# Altium schematic sheet 1. Power.SchDoc("1. Power")
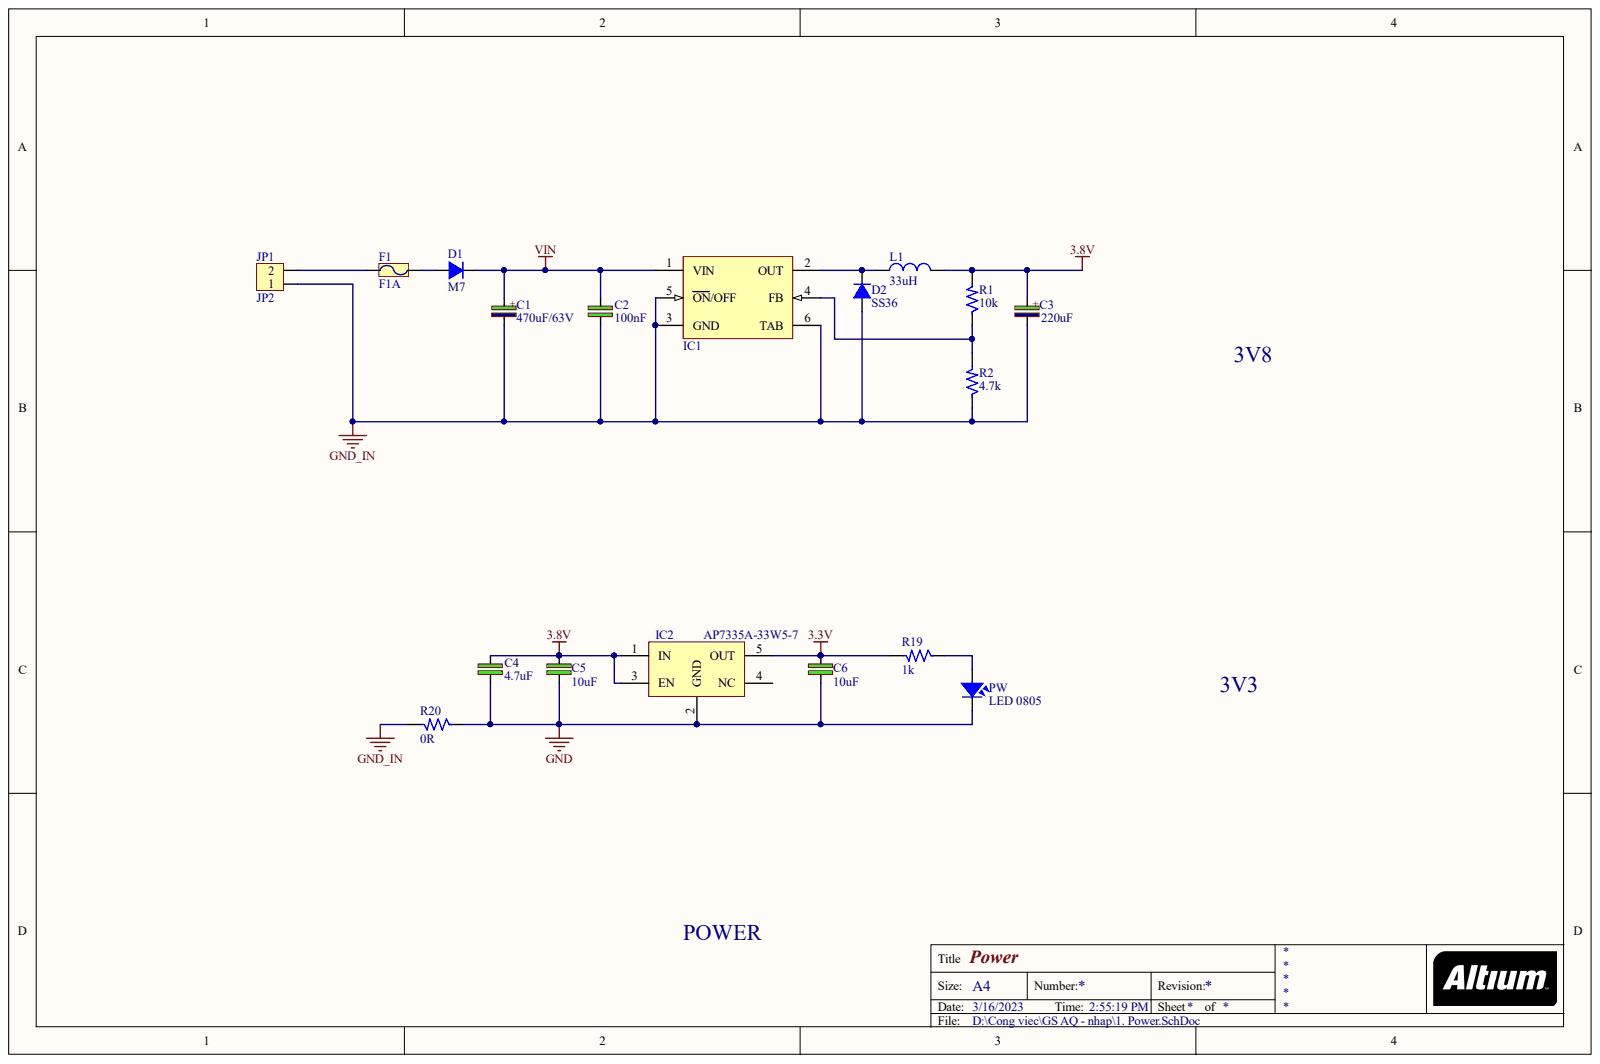
[diagram p0: 1. Power.SchDoc]

## Parts
C1  470uF/63V  [CP_1000uF_25V]
C2  value 100nF  [C-0805]  (hidden comment: 4.7uF)
C3  220uF  [EEFSDE]
C4  4.7uF  [C-0805]
C5  10uF  [C-0805]
C6  10uF  [C-0805]
D1  M7  [SMA11]
D2  SS36  [SMA11]
F1  F1A  [Fuse3]
IC1  LM2576  [TO-263]  (value not drawn)
IC2  AP7335A-33W5-7  [SOT23-5]
JP1  JP2  [KF2-5.08]
L1  33uH  [XL-cd74]
PW  LED 0805  [LED-0805]
R1  10k  [R-0805]
R2  4.7k  [R-0805]
R19  1k  [R-0805]
R20  0R  [R-0805]

## Nets
3.3V: C6.1 IC2.5(OUT) R19.2
3.8V: C3.1 C4.2 C5.1 IC2.1(IN) IC2.3(EN) L1.2 R1.2
GND: C4.1 C5.2 C6.2 IC2.2(GND) PW.2 R20.1
GND_IN: C1.2 C2.1 C3.2 D2.1 IC1.3(GND) IC1.5(ON/OFF) IC1.6(TAB) JP1.1 R2.1 R20.2
VIN: C1.1 C2.2 D1.2 IC1.1(VIN)
NetD1_1: D1.1 F1.2
NetD2_2: D2.2 IC1.2(OUT) L1.1
NetF1_1: F1.1 JP1.2
NetIC1_4: IC1.4(FB) R1.1 R2.2
NetPW_1: PW.1 R19.1

Not connected: IC2 4(NC)

## Library data of the parts
C1 = CP3: Cap 470uF/63V; Component Kind: Standard; Value: Cap 470uF/63V; Library Name: Anh_Trung.SchLib
C2 C4 = C: 4.7µF ±10% 35V Ceramic Capacitor X5R 0805 (2012 Metric); Manufacturer: Samsung Electro-Mechanics; Part number: CL21A475KLCLQNC; User_Description: CAP CER 4.7UF 35V X5R 0805; Category: CAPACITOR; Component Kind: Standard; Package: 0805; Library Name: Anh_Trung.SchLib
  C4: Value: 4.7uF
C3 = CP5: 220µF Molded Tantalum Polymer Capacitor 16V 2917 (7343 Metric) 40mOhm @ 100kHz; Manufacturer: AVX Corporation; Part number: TCME227M016R0040E; User_Description: CAP TANT POLY 220UF 16V 2917; Category: CAPACITOR; Component Kind: Standard; Package: 2917; Value: 220uF; Library Name: Anh_Trung.SchLib
C5 C6 = C: Cap SMD; Manufacturer: Samsung Electro-Mechanics; Part number: CL21A106KPFNNNG; User_Description: CAP CER 10UF 10V X5R 0805; Category: CAPACITOR; Component Kind: Standard; Package: 0805; Value: 10uF; Library Name: Anh_Trung.SchLib
D1 = D1A: Diode 1A; Value: 1N4007; Component Kind: Standard; Library Name: Anh_Trung.SchLib
D2 = B340A: Diode Schottky 40V 3A Surface Mount DO-214AC (SMA); Manufacturer: Vishay General Semiconductor - Diodes Division; Part number: B340A-M3/61T; User_Description: DIODE SCHOTTKY 40V 3A DO214AC; Value: 40V 3A; Category: DIODE; Component Kind: Standard; Package: DO214AC; Library Name: Anh_Trung.SchLib
F1 = Fuse: Component Kind: Standard; Description: Fuse; Value: Fuse; Library Name: Anh_Trung.SchLib
JP1 = Con2: Connector 2; Component Kind: Standard; Value: Connector 2; Library Name: Anh_Trung.SchLib
L1 = L1: 22µH Shielded Inductor 1.65A 96mOhm Max Nonstandard; Manufacturer: Bourns Inc.; Part Number: SRR0745A-220M; User_Description: FIXED IND 22UH 1.65A 96 MOHM SMD; Value: 220uH; Category: INDUCTORS; Component Kind: Standard; Package: SMD; Library Name: Anh_Trung.SchLib
PW = LED: LED RED DIFFUSED 0805 SMD; Manufacturer: OSRAM Opto Semiconductors Inc.; Part number: LS R976-NR-1; Category: LED SMD; Component Kind: Standard; Package: 0805; User_Description: LED RED DIFFUSED 0805 SMD; Value: Red; Library Name: Anh_Trung.SchLib
R1 = R: RES SMD 10K OHM 1% 1/8W 0805; Manufacturer: Yageo; Part number: RC0805FR-0710KL; Category: RESISTORS; Component Kind: Standard; Package: 0805; User_Description: RES SMD 10K OHM 1% 1/8W 0805; Value: 10k; Library Name: Anh_Trung.SchLib
R2 = R: RES SMD 3.3K OHM 1% 1/8W 0805; Manufacturer: Yageo; Part number: RC0805FR-073K3L; Category: RESISTORS; Component Kind: Standard; Package: 0805; User_Description: RES SMD 3.3K OHM 1% 1/8W 0805; Value: 3.3k; Library Name: Anh_Trung.SchLib
R19 = R: Resistor 1/4W; Manufacturer: Yageo; Part number: RC0805FR-071KL; User_Description: RES SMD 1K OHM 1% 1/8W 0805; Category: RESISTORS; Component Kind: Standard; Package: 0805; Value: 1k; Library Name: Anh_Trung.SchLib
R20 = R: Resistor 1/4W; Manufacturer: Yageo; Part number: RC0805FR-071KL; User_Description: RES SMD 1K OHM 1% 1/8W 0805; Value: 1k; Category: RESISTORS; Component Kind: Standard; Package: 0805; Library Name: Anh_Trung.SchLib
IC1 = LM2576: Component Kind: Standard; Description: LM2576; Library Name: Anh_Trung.SchLib
IC2 = AP7335A-33W5-7: Component Kind: Standard; Description: AP7335A-33W5-7; Package: SOT23-5; Part number: AP7335A-33W5-7; Library Name: Anh_Trung.SchLib
